# Altium schematic sheet Swich_PSU.SchDoc(Swich_PSU) (continued)

## Library data of the parts
B1 = BRIDGE3: Diode Bridge; Manufacturer: Diodes Inc; Current - Average Rectified (Io): 2A; Current - Reverse Leakage @ Vr: 5µA @ 600V; Diode Type: Single Phase; LHADescription: RECT BRIDGE GPP 600V 2A KBP; Speed: Standard Recovery >500ns, > 200mA (Io); Voltage - Forward (Vf) (Max) @ If: 1.1V @ 2A; Voltage - Peak Reverse (Max): 600V; Category: Discrete Semiconductor Products; Component Kind: Standard; ComponentLink1Description: http://www.diodes.com/datasheets/ds21205.pdf; ComponentLink1URL: http://www.diodes.com/datasheets/ds21205.pdf; ComponentLink2Description: https://www.diodes.com/assets/Datasheets/ds21205.pdf; ComponentLink2URL: https://www.diodes.com/assets/Datasheets/ds21205.pdf; Current - DC Forward (If): 2A; Manufacturer Part Number: KBP206G; Mounting Type: Through Hole; Operating Temperature: -65°C ~ 150°C (TJ); PackageCase: KBP; Packaging: Tube; Part Description: RECT BRIDGE GPP 600V 2A KBP; Part Status: Active; Pricing: 1=0.57, 10=0.48, 100=0.36, 250=0.312, 500=0.264, 1000=0.204, 2500=0.186, 5000=0.174, 10000=0.162 (USD); Pricing 1: 1=0.58, 35=0.462, 105=0.36962, 525=0.2904, 1015=0.2244 (USD); Reverse Recovery Time (trr): -; RoHS: RoHS Compliant; Stock: 7746; Stock 1: 13751; Supplier 1: Digi-Key; Supplier Device Package: KBP; Supplier Part Number 1: KBP206GDI-ND; Technology: Standard; Type: DIODE
C1 C7 C15 C18 = CAP: Cap Ceramic 10%; Manufacturer: Yageo; Manufacturer Part Number: CC0805ZRY5V9BB104; Part Description: CAP Y5V Ceramic 100nF 50V 0805 -20%, +80%  -; Dielectric: Y5V; LHADescription: CAP CER 0.1UF 50V Y5V 0805; Temperature Coefficient: Y5V (F); Tolerance: -20%, +80%; Voltage Rated: 50V; Applications: General Purpose; Capacitance: 100nF; Case Code (Imperial): 0805; Case Code (Metric): 2012; Category: Capacitors; Component Kind: Standard; ComponentLink1Description: http://www.yageo.com/documents/recent/UPY-GPHC_Y5V_6.3V-to-50V_5.pdf; ComponentLink1URL: http://www.yageo.com/documents/recent/UPY-GPHC_Y5V_6.3V-to-50V_5.pdf; ComponentLink2Description: http://www.yageo.com/documents/recent/UPY-GPHC_Y5V_6.3V-to-50V_8.pdf; ComponentLink2URL: http://www.yageo.com/documents/recent/UPY-GPHC_Y5V_6.3V-to-50V_8.pdf; ComponentLink3Description: https://datasheet.ciiva.com/144/mlccorderingcode-144209.pdf?src-supplier=Digi-Key; ComponentLink3URL: https://datasheet.ciiva.com/144/mlccorderingcode-144209.pdf?src-supplier=Digi-Key; ComponentLink4Description: https://datasheet.ciiva.com/144/mlccorderingcode-144210.pdf?src-supplier=Digi-Key; ComponentLink4URL: https://datasheet.ciiva.com/144/mlccorderingcode-144210.pdf?src-supplier=Digi-Key; ComponentLink5Description: https://datasheet.ciiva.com/144/mlccorderingcode-144211.pdf?src-supplier=Digi-Key; ComponentLink5URL: https://datasheet.ciiva.com/144/mlccorderingcode-144211.pdf?src-supplier=Digi-Key; ComponentLink6Description: https://datasheet.ciiva.com/15189/getdatasheetpartid-55882-15189238.pdf?src-supplier=Verical; ComponentLink6URL: https://datasheet.ciiva.com/15189/getdatasheetpartid-55882-15189238.pdf?src-supplier=Verical; ComponentLink7Description: https://datasheet.ciiva.com/28450/1132289-28450870.pdf?src-supplier=Element14; ComponentLink7URL: https://datasheet.ciiva.com/28450/1132289-28450870.pdf?src-supplier=Element14; ComponentLink8Description: https://datasheet.ciiva.com/13389/upy-gp-np0-16v-to-50v-8-13389351.pdf?src-supplier=Mouser; ComponentLink8URL: https://datasheet.ciiva.com/13389/upy-gp-np0-16v-to-50v-8-13389351.pdf?src-supplier=Mouser; ComponentLink9Description: https://datasheet.ciiva.com/11465/upy-gphc-y5v-6-3v-to-50v-5-11465865.pdf?src-supplier=Avnet; ComponentLink9URL: https://datasheet.ciiva.com/11465/upy-gphc-y5v-6-3v-to-50v-5-11465865.pdf?src-supplier=Avnet; ComponentLink10Description: https://datasheet.ciiva.com/16747/upy-gphc-y5v-6-3v-to-50v-5-revised-16747323.pdf?src-supplier=Future+Electronics; ComponentLink10URL: https://datasheet.ciiva.com/16747/upy-gphc-y5v-6-3v-to-50v-5-revised-16747323.pdf?src-supplier=Future+Electronics; ComponentLink11Description: https://datasheet.ciiva.com/17435/upy-gphc-y5v-6-3v-to-50v-5-17435053.pdf?src-supplier=Avnet+Europe; ComponentLink11URL: https://datasheet.ciiva.com/17435/upy-gphc-y5v-6-3v-to-50v-5-17435053.pdf?src-supplier=Avnet+Europe; ComponentLink12Description: https://datasheet.ciiva.com/29241/445331-da-01-en-kerko-chip-0805-y5v0-1uf-20-80proz50vg-29241946.pdf?src-supplier=Conrad; ComponentLink12URL: https://datasheet.ciiva.com/29241/445331-da-01-en-kerko-chip-0805-y5v0-1uf-20-80proz50vg-29241946.pdf?src-supplier=Conrad; Composition: Ceramic; Depth: 1.25mm; Dielectric Material: Ceramic; Failure Rate: -; Features: -; Halogen Free: Halogen Free; Height: 0.6mm; Height - Seated (Max): -; Lead Free: Lead Free; Lead Pitch: -; Lead Style: -; Length: 2mm; Library Name: LHA_LIB.SchLib; Material: Ceramic; Max Operating Temperature: 85°C; Min Operating Temperature: -30°C; Mount: Surface Mount; Mounting Type: Surface Mount, MLCC; Number of Pins: 2; Operating Temperature: -30°C ~ 85°C; Package Quantity: 1; PackageCase: 0805; Packaging: Cut Tape (CT); Part Status: Active; Pricing 1: 1=0.1, 10=0.031, 25=0.0216, 100=0.0141, 250=0.01152, 500=0.00986, 1000=0.00768 (USD); Pricing 2: 4000=0.01279, 8000=0.01168, 12000=0.01112, 28000=0.01056, 100000=0.00778 (USD); Radiation Hardening: No; Ratings: -; REACH SVHC: No SVHC; RoHS: RoHS Compliant; Size / Dimension: 0.079" L x 0.049" W (2.00mm x 1.25mm); Stock 1: 12304; Stock 2: 1536000; Supplier 1: Digi-Key; Supplier 2: Digi-Key; Supplier Part Number 1: 311-1361-1-ND; Supplier Part Number 2: 311-1361-2-ND; Termination: SMD/SMT; Thickness: 0.024inch; Thickness (Max): 0.024" (0.60mm); Type: CAP; Width: 0.049inch
C2 = CAP: Cap Ceramic 10%; Manufacturer: Yageo; Manufacturer Part Number: CC0805ZRY5V9BB104; Part Description: CAP Y5V Ceramic 100nF 50V 0805 -20%, +80%  -; Dielectric: Y5V; LHADescription: CAP CER 0.1UF 50V Y5V 0805; Temperature Coefficient: Y5V (F); Tolerance: -20%, +80%; Applications: General Purpose; Capacitance: 100nF; Case Code (Imperial): 0805; Case Code (Metric): 2012; Category: Capacitors; Component Kind: Standard; ComponentLink1Description: http://www.yageo.com/documents/recent/UPY-GPHC_Y5V_6.3V-to-50V_5.pdf; ComponentLink1URL: http://www.yageo.com/documents/recent/UPY-GPHC_Y5V_6.3V-to-50V_5.pdf; ComponentLink2Description: http://www.yageo.com/documents/recent/UPY-GPHC_Y5V_6.3V-to-50V_8.pdf; ComponentLink2URL: http://www.yageo.com/documents/recent/UPY-GPHC_Y5V_6.3V-to-50V_8.pdf; ComponentLink3Description: https://datasheet.ciiva.com/144/mlccorderingcode-144209.pdf?src-supplier=Digi-Key; ComponentLink3URL: https://datasheet.ciiva.com/144/mlccorderingcode-144209.pdf?src-supplier=Digi-Key; ComponentLink4Description: https://datasheet.ciiva.com/144/mlccorderingcode-144210.pdf?src-supplier=Digi-Key; ComponentLink4URL: https://datasheet.ciiva.com/144/mlccorderingcode-144210.pdf?src-supplier=Digi-Key; ComponentLink5Description: https://datasheet.ciiva.com/144/mlccorderingcode-144211.pdf?src-supplier=Digi-Key; ComponentLink5URL: https://datasheet.ciiva.com/144/mlccorderingcode-144211.pdf?src-supplier=Digi-Key; ComponentLink6Description: https://datasheet.ciiva.com/15189/getdatasheetpartid-55882-15189238.pdf?src-supplier=Verical; ComponentLink6URL: https://datasheet.ciiva.com/15189/getdatasheetpartid-55882-15189238.pdf?src-supplier=Verical; ComponentLink7Description: https://datasheet.ciiva.com/28450/1132289-28450870.pdf?src-supplier=Element14; ComponentLink7URL: https://datasheet.ciiva.com/28450/1132289-28450870.pdf?src-supplier=Element14; ComponentLink8Description: https://datasheet.ciiva.com/13389/upy-gp-np0-16v-to-50v-8-13389351.pdf?src-supplier=Mouser; ComponentLink8URL: https://datasheet.ciiva.com/13389/upy-gp-np0-16v-to-50v-8-13389351.pdf?src-supplier=Mouser; ComponentLink9Description: https://datasheet.ciiva.com/11465/upy-gphc-y5v-6-3v-to-50v-5-11465865.pdf?src-supplier=Avnet; ComponentLink9URL: https://datasheet.ciiva.com/11465/upy-gphc-y5v-6-3v-to-50v-5-11465865.pdf?src-supplier=Avnet; ComponentLink10Description: https://datasheet.ciiva.com/16747/upy-gphc-y5v-6-3v-to-50v-5-revised-16747323.pdf?src-supplier=Future+Electronics; ComponentLink10URL: https://datasheet.ciiva.com/16747/upy-gphc-y5v-6-3v-to-50v-5-revised-16747323.pdf?src-supplier=Future+Electronics; ComponentLink11Description: https://datasheet.ciiva.com/17435/upy-gphc-y5v-6-3v-to-50v-5-17435053.pdf?src-supplier=Avnet+Europe; ComponentLink11URL: https://datasheet.ciiva.com/17435/upy-gphc-y5v-6-3v-to-50v-5-17435053.pdf?src-supplier=Avnet+Europe; ComponentLink12Description: https://datasheet.ciiva.com/29241/445331-da-01-en-kerko-chip-0805-y5v0-1uf-20-80proz50vg-29241946.pdf?src-supplier=Conrad; ComponentLink12URL: https://datasheet.ciiva.com/29241/445331-da-01-en-kerko-chip-0805-y5v0-1uf-20-80proz50vg-29241946.pdf?src-supplier=Conrad; Composition: Ceramic; Depth: 1.25mm; Dielectric Material: Ceramic; Failure Rate: -; Features: -; Halogen Free: Halogen Free; Height: 0.6mm; Height - Seated (Max): -; Lead Free: Lead Free; Lead Pitch: -; Lead Style: -; Length: 2mm; Library Name: LHA_LIB.SchLib; Material: Ceramic; Max Operating Temperature: 85°C; Min Operating Temperature: -30°C; Mount: Surface Mount; Mounting Type: Surface Mount, MLCC; Number of Pins: 2; Operating Temperature: -30°C ~ 85°C; Package Quantity: 1; PackageCase: 0805; Packaging: Cut Tape (CT); Part Status: Active; Pricing 1: 1=0.1, 10=0.031, 25=0.0216, 100=0.0141, 250=0.01152, 500=0.00986, 1000=0.00768 (USD); Pricing 2: 4000=0.01279, 8000=0.01168, 12000=0.01112, 28000=0.01056, 100000=0.00778 (USD); Radiation Hardening: No; Ratings: -; REACH SVHC: No SVHC; RoHS: RoHS Compliant; Size / Dimension: 0.079" L x 0.049" W (2.00mm x 1.25mm); Stock 1: 12304; Stock 2: 1536000; Supplier 1: Digi-Key; Supplier 2: Digi-Key; Supplier Part Number 1: 311-1361-1-ND; Supplier Part Number 2: 311-1361-2-ND; Termination: SMD/SMT; Thickness: 0.024inch; Thickness (Max): 0.024" (0.60mm); Type: CAP; Width: 0.049inch
C4 = CAP: Cap Ceramic 10%; Manufacturer: Murata; Manufacturer Part Number: GRM31A5C3A220JW01D; Part Description: CAP C0G  22pF  1206 5%; Dielectric: C0G; LHADescription: CAP CER 22PF 1KV C0G/NP0 1206; Tolerance: 5%; Voltage Rating: 1kV; Capacitance: 22pF; Case Code (Imperial): 1206; Case Code (Metric): 3216; Category: Capacitors; Component Kind: Standard; ComponentLink1Description: https://datasheet.ciiva.com/7829/1666664-7829030.pdf?src-supplier=Element14; ComponentLink1URL: https://datasheet.ciiva.com/7829/1666664-7829030.pdf?src-supplier=Element14; ComponentLink2Description: https://datasheet.ciiva.com/13352/c02e-13352863.pdf?src-supplier=Mouser; ComponentLink2URL: https://datasheet.ciiva.com/13352/c02e-13352863.pdf?src-supplier=Mouser; ComponentLink6Description: https://datasheet.ciiva.com/2988/kem-c1003-c0g-smd-2988707.pdf?src-supplier=Avnet; ComponentLink6URL: https://datasheet.ciiva.com/2988/kem-c1003-c0g-smd-2988707.pdf?src-supplier=Avnet; ComponentLink7Description: https://datasheet.ciiva.com/14602/70095383-14602200.pdf?src-supplier=Allied+Electronics; ComponentLink7URL: https://datasheet.ciiva.com/14602/70095383-14602200.pdf?src-supplier=Allied+Electronics; ComponentLink8Description: https://datasheet.ciiva.com/11463/kem-c1003-c0g-smd-11463530.pdf?src-supplier=Avnet; ComponentLink8URL: https://datasheet.ciiva.com/11463/kem-c1003-c0g-smd-11463530.pdf?src-supplier=Avnet; ComponentLink9Description: https://datasheet.ciiva.com/18194/getdatasheetpartid-135192-18194074.pdf?src-supplier=Verical; ComponentLink9URL: https://datasheet.ciiva.com/18194/getdatasheetpartid-135192-18194074.pdf?src-supplier=Verical; ComponentLink10Description: https://datasheet.ciiva.com/28672/1789732-28672843.pdf?src-supplier=Element14; ComponentLink10URL: https://datasheet.ciiva.com/28672/1789732-28672843.pdf?src-supplier=Element14; ComponentLink11Description: https://datasheet.ciiva.com/7729/1691965-7729775.pdf?src-supplier=Element14; ComponentLink11URL: https://datasheet.ciiva.com/7729/1691965-7729775.pdf?src-supplier=Element14; ComponentLink12Description: https://datasheet.ciiva.com/14586/70095383-14586767.pdf?src-supplier=Allied+Electronics; ComponentLink12URL: https://datasheet.ciiva.com/14586/70095383-14586767.pdf?src-supplier=Allied+Electronics; Depth: 1.6mm; Height: 1mm; Length: 3.2mm; Library Name: LHA_LIB.SchLib; Max Operating Temperature: 125°C; Min Operating Temperature: -55°C; Mount: Surface Mount; Package Quantity: 4000; PackageCase: 1206; Packaging: Tape and Reel; Pricing 1: 1=0.41000, 10=0.29200, 50=0.22080, 100=0.19230, 500=0.14240, 1000=0.12105 (USD); REACH SVHC: No SVHC; RoHS: Yes; RoHS Compliant: Yes; Series: GRM; Stock 1: 271065; Supplier 1: Digi-Key; Supplier Part Number 1: 490-14673-1-ND; Termination: SMD/SMT; Thickness: 0.039inch; Width: 0.063inch
C5 C26 = CAP: Cap Polyester; Manufacturer: TDK Corporation; Manufacturer Part Number: CD12-E2GA222MYNS; Part Description: CAP X1 Ceramic 2.2nF 250VAC Radial, Disc ±20%  10.00mm; Dielectric: X1; Dielectric Material: Ceramic; LHADescription: CAP CER 2200PF 250VAC RADIAL  X1; Temperature Coefficient: E; Tolerance: ±20%; Voltage Rated: 250VAC; Applications: Safety; Capacitance: 2.2nF; Category: Capacitors; Component Kind: Standard; ComponentLink1Description: http://www.tdk.com/pdf/e4b3_cd.pdf; ComponentLink1URL: http://www.tdk.com/pdf/e4b3_cd.pdf; ComponentLink2Description: http://media.digikey.com/pdf/Data%20Sheets/TDK%20PDFs/CD_Series.pdf; ComponentLink2URL: http://media.digikey.com/pdf/Data%20Sheets/TDK%20PDFs/CD_Series.pdf; ComponentLink3Description: http://www.molex.com/pdm_docs/ats/ATS-639041600.pdf?src-supplier=Digi-Key; ComponentLink3URL: http://www.molex.com/pdm_docs/ats/ATS-639041600.pdf?src-supplier=Digi-Key; Failure Rate: -; Features: High Voltage; Height - Seated (Max): 0.610" (15.50mm); Lead Pitch: 10.00mm; Lead Style: Straight; Library Name: LHA_LIB.SchLib; Mounting Type: Through Hole; Operating Temperature: -25°C ~ 105°C; PackageCase: Radial, Disc; Packaging: Bulk; Part Status: Obsolete; Pricing: 1=0.5, 10=0.353, 100=0.2325, 250=0.19808, 500=0.17224, 1000=0.1464, 5000=0.12918, 10000=0.12057, 25000=0.11626 (USD); Pricing 1: 1=0.55, 10=0.388 (USD); Pricing 2: 1=124.74000, 5=117.18000, 10=113.40000, 25=109.62000 (USD); Ratings: X1; RoHS: RoHS Compliant; Size / Dimension: 0.453" Dia (11.50mm); Stock: 322844; Stock 1: 12; Stock 2: 2; Supplier 1: Digi-Key; Supplier 2: Digi-Key; Supplier Part Number 1: 445-2411-ND; Supplier Part Number 2: WM19323-ND; Thickness (Max): -; Type: CAP
C6 = CAP: Cap Ceramic 10%; Manufacturer: KEMET; Manufacturer Part Number: C1210C392KGRACTU; Part Description: CAP X7R Ceramic 3.9nF 2kV 1210 10%  -; Dielectric: X7R; LHADescription: CAP CER 3900PF 2KV X7R 1210; Applications: SMPS Filtering; Capacitance: 3.9nF; Case Code (Imperial): 1210; Case Code (Metric): 3225; Category: Capacitors; Component Kind: Standard; ComponentLink1Description: http://components.arrow.com/part/dataview/48187724S8617532N7429; ComponentLink1URL: http://components.arrow.com/part/dataview/48187724S8617532N7429; ComponentLink2Description: http://www.mouser.com/ds/2/212/KEM_C1010_X7R_HV_SMD-345925.pdf; ComponentLink2URL: http://www.mouser.com/ds/2/212/KEM_C1010_X7R_HV_SMD-345925.pdf; ComponentLink3Description: http://www.kemet.com/docfinder?Partnumber=C1210C392KGRACTU; ComponentLink3URL: http://www.kemet.com/docfinder?Partnumber=C1210C392KGRACTU; Depth: 2.5mm; Dielectric Material: Ceramic; Failure Rate: -; Features: High Voltage; Height - Seated (Max): -; Lead Pitch: -; Lead Style: -; Length: 0.126inch; Library Name: LHA_LIB.SchLib; Max Operating Temperature: 125°C; Min Operating Temperature: -55°C; Mounting Type: Surface Mount, MLCC; Operating Temperature: -55°C ~ 125°C; Package Quantity: 1; PackageCase: 1210; Packaging: Tape and Reel; Part Status: Active; Pricing 1: 2000=0.39588, 4000=0.3754 (USD); Ratings: -; RoHS: RoHS Compliant; Size / Dimension: 0.126" L x 0.098" W (3.20mm x 2.50mm); Stock 1: 0; Supplier 1: Digi-Key; Supplier Part Number 1: 399-7234-2-ND; Temperature Coefficient: X7R; Termination: SMD/SMT; Thickness: 0.091inch; Thickness (Max): 0.091" (2.30mm); Tolerance: 10%; Voltage Rated: 2kV; Width: 0.098inch
C8 C9 = CAP POL: Electrolytic Capacitor; Manufacturer: KEMET; Manufacturer Part Number: ESW337M050AH4EA; Part Description: CAP   330uF LOW ESR 50V Radial 20%  5mm; ESR (Equivalent Series Resistance): 90mR; LHADescription: Aluminum Electrolytic Capacitors - Leaded 50volts 330uF 20%; Life (Hours): 5000Hour; Polarity: Polar; Ripple Current: 566.5mA; Tolerance: 20%; Capacitance: 330uF LOW ESR; Category: Capacitors; Component Kind: Standard; Height - Seated (Max): 21mm; Impedance: 90mR; Lead Pitch: 5mm; Length: 19mm; Library Name: LHA_LIB.SchLib; Max Operating Temperature: 105°C; Min Operating Temperature: -40°C; Mount: Through Hole; Operating Temperature: -40°C ~ 105°C; Package Quantity: 1; PackageCase: Radial; Packaging: Tape and Reel; Pricing 1: 1=0.93000, 10=0.68500, 50=0.54800, 100=0.46980 (USD); RoHS: Yes; RoHS Compliant: Yes; Series: ESW; Stock 1: 4304; Supplier 1: Digi-Key; Supplier Part Number 1: 399-6661-1-ND; Termination: Radial
  C8: ComponentLink1Description: http://www.vishay.com/docs/25008/eke.pdf; ComponentLink1URL: http://www.vishay.com/docs/25008/eke.pdf; ComponentLink2Description: http://www.mouser.com/ds/2/212/KEM_A4009_ESW-1102613.pdf?src-supplier=Mouser; ComponentLink2URL: http://www.mouser.com/ds/2/212/KEM_A4009_ESW-1102613.pdf?src-supplier=Mouser; ComponentLink3Description: https://search.kemet.com/component-edge/download/datasheet/ESW337M050AH4EA.pdf?src-supplier=Digi-Key; ComponentLink3URL: https://search.kemet.com/component-edge/download/datasheet/ESW337M050AH4EA.pdf?src-supplier=Digi-Key
  C9: ComponentLink1Description: http://www.mouser.com/ds/2/212/KEM_A4009_ESW-1102613.pdf?src-supplier=Mouser; ComponentLink1URL: http://www.mouser.com/ds/2/212/KEM_A4009_ESW-1102613.pdf?src-supplier=Mouser; ComponentLink2Description: https://search.kemet.com/component-edge/download/datasheet/ESW337M050AH4EA.pdf?src-supplier=Digi-Key; ComponentLink2URL: https://search.kemet.com/component-edge/download/datasheet/ESW337M050AH4EA.pdf?src-supplier=Digi-Key
C10 = CAP POL: Electrolytic Capacitor; Manufacturer: Rubycon; Manufacturer Part Number: 50ZL100MEFCT78X11.5; Part Description: CAP   100uF LOW ESR 50V Radial 20%  3.5mm; Impedance: 74mR; LHADescription: Cap Aluminum 100uF 50V 20% (8 X 11.5mm) Radial Aluminum Cylindrical Can 3.5mm 724mA 3000 hr 105°C Ammo Pack; Life (Hours): 3000Hour; Ripple Current: 724mA; Tolerance: 20%; Capacitance: 100uF LOW ESR; Category: Capacitors; Component Kind: Standard; ComponentLink1Description: http://www.vishay.com/docs/25008/eke.pdf; ComponentLink1URL: http://www.vishay.com/docs/25008/eke.pdf; ComponentLink2Description: https://datasheet.ciiva.com/16375/e-vr-16375770.pdf?src-supplier=Future+Electronics; ComponentLink2URL: https://datasheet.ciiva.com/16375/e-vr-16375770.pdf?src-supplier=Future+Electronics; ComponentLink3Description: https://datasheet.ciiva.com/7781/e-vr-7781740.pdf?src-supplier=Element14; ComponentLink3URL: https://datasheet.ciiva.com/7781/e-vr-7781740.pdf?src-supplier=Element14; ComponentLink4Description: https://datasheet.ciiva.com/17525/e-vr-17525677.pdf?src-supplier=Avnet+Europe; ComponentLink4URL: https://datasheet.ciiva.com/17525/e-vr-17525677.pdf?src-supplier=Avnet+Europe; ComponentLink5Description: https://datasheet.ciiva.com/11686/e-vr-11686088.pdf?src-supplier=Avnet; ComponentLink5URL: https://datasheet.ciiva.com/11686/e-vr-11686088.pdf?src-supplier=Avnet; ComponentLink6Description: https://datasheet.ciiva.com/46381/1909356-46381755.pdf?src-supplier=Element14; ComponentLink6URL: https://datasheet.ciiva.com/46381/1909356-46381755.pdf?src-supplier=Element14; ComponentLink7Description: https://datasheet.ciiva.com/14600/70187464-14600942.pdf?src-supplier=Allied+Electronics; ComponentLink7URL: https://datasheet.ciiva.com/14600/70187464-14600942.pdf?src-supplier=Allied+Electronics; ComponentLink8Description: https://datasheet.ciiva.com/6328/e-vr-6328815.pdf?src-supplier=Digi-Key; ComponentLink8URL: https://datasheet.ciiva.com/6328/e-vr-6328815.pdf?src-supplier=Digi-Key; ComponentLink9Description: https://datasheet.ciiva.com/6328/e-yxj-6328247.pdf?src-supplier=Digi-Key; ComponentLink9URL: https://datasheet.ciiva.com/6328/e-yxj-6328247.pdf?src-supplier=Digi-Key; ComponentLink10Description: https://datasheet.ciiva.com/6328/e-yxj-6328248.pdf?src-supplier=Digi-Key; ComponentLink10URL: https://datasheet.ciiva.com/6328/e-yxj-6328248.pdf?src-supplier=Digi-Key; ComponentLink11Description: https://datasheet.ciiva.com/6318/e-zl-6318059.pdf?src-supplier=Digi-Key; ComponentLink11URL: https://datasheet.ciiva.com/6318/e-zl-6318059.pdf?src-supplier=Digi-Key; Height - Seated (Max): 13mm; Lead Pitch: 3.5mm; Library Name: LHA_LIB.SchLib; Max Operating Temperature: 105°C; Min Operating Temperature: -40°C; Mount: Through Hole; Operating Temperature: -40°C ~ 105°C; Package Quantity: 2000; PackageCase: Radial; Packaging: Tape and Reel; Pricing 1: 1=0.41000, 10=0.28500, 50=0.19920, 100=0.17080, 500=0.12808 (USD); RoHS: Yes; Stock 1: 3785; Supplier 1: Digi-Key; Supplier Part Number 1: 1189-3773-1-ND
C11 = CAP POL: Aluminium Electrolytic Cap; Part Description: CAP Electrolytic Aluminium 180uF 400V R18mm x H39mm max x P7.5mm 20%; Hight: 39mm max; LHADescription: Aluminum Electrolytic Capacitor, Polarized, Aluminum (wet), 400V, 20% +Tol, 20% -Tol, 180uF, Through Hole Mount; Tolerance: 20%; Capacitance: 180uF; Category: Capacitors; Component Kind: Standard; Diameter: 20mm max; Dielectric: Electrolytic; Dielectric Material: Aluminium; Lead Pitch: 7.5mm; Library Name: LHA_LIB.SchLib; PackageCase: R18mm x H39mm max x P7.5mm; Pricing 1: 1=4.55000, 10=4.09900, 50=3.55240, 100=3.09690, 500=2.55040, 1000=2.37615 (USD); RoHS: Yes; Stock 1: 119; Supplier 1: Digi-Key
C12 = CAP POL: Electrolytic Capacitor; Manufacturer: Wurth Electronics; Manufacturer Part Number: 860020672011; Part Description: CAP   22uF LOW ESR 50V Radial 20% 5mm 3.5mm; LHADescription: Aluminium Electrolytic Capacitor, Wcap-Atg5 Series, 22 F, 20%, 50 V, 5 Mm, Radial Leaded Rohs Compliant: Yes; Life (Hours): 2000Hour; Polarity: Polar; Ripple Current: 60mA; Tolerance: 20%; Voltage Rated: 50V; Capacitance: 22uF LOW ESR; Category: Capacitors; Component Kind: Standard; ComponentLink1Description: http://www.rubycon.co.jp/en/catalog/e_pdfs/aluminum/e_zl.pdf; ComponentLink1URL: http://www.rubycon.co.jp/en/catalog/e_pdfs/aluminum/e_zl.pdf; ComponentLink2Description: http://www.farnell.com/datasheets/1879653.pdf?src-supplier=Farnell; ComponentLink2URL: http://www.farnell.com/datasheets/1879653.pdf?src-supplier=Farnell; ComponentLink3Description: https://katalog.we-online.de/pbs/datasheet/860020672011.pdf?src-supplier=Digi-Key; ComponentLink3URL: https://katalog.we-online.de/pbs/datasheet/860020672011.pdf?src-supplier=Digi-Key; ComponentLink4Description: http://katalog.we-online.de/pbs/datasheet/860020672011.pdf?src-supplier=Digi-Key; ComponentLink4URL: http://katalog.we-online.de/pbs/datasheet/860020672011.pdf?src-supplier=Digi-Key; ComponentLink5Description: http://www.farnell.com/datasheets/1879653.pdf?src-supplier=Element14; ComponentLink5URL: http://www.farnell.com/datasheets/1879653.pdf?src-supplier=Element14; Diameter: 5mm; Height: 11mm; Height - Seated (Max): 0.492inch; Lead Pitch: 3.5mm; Library Name: LHA_LIB.SchLib; Max Operating Temperature: 105°C; Min Operating Temperature: -40°C; Mount: Through Hole; Operating Temperature: -40°C ~ 105°C; Package Quantity: 2000; PackageCase: Radial; Packaging: Tape and Reel; Pricing 1: 1=0.11000, 50=0.09000, 100=0.07900, 250=0.07000, 500=0.06100, 1000=0.05800 (USD); REACH SVHC: Unknown; RoHS: Yes; RoHS Compliant: Yes; Stock 1: 1276; Supplier 1: Digi-Key; Supplier Part Number 1: 732-8857-1-ND
C13 = CAP: Cap Ceramic 10%; Manufacturer: Samsung; Manufacturer Part Number: CL21B562KBANNNC; Part Description: CAP X7R  5.6nF 50V 0805 10%; Dielectric: X7R; LHADescription: Cap Ceramic 0.0056uF 50V X7R 10% SMD 0805 125C Paper T/R; Voltage Rated: 50V; Capacitance: 5.6nF; Case Code (Imperial): 0805; Case Code (Metric): 2012; Category: Capacitors; Component Kind: Standard; ComponentLink1Description: http://product.tdk.com/capacitor/mlcc/en/documents/mlcc_commercial_general_en.pdf; ComponentLink1URL: http://product.tdk.com/capacitor/mlcc/en/documents/mlcc_commercial_general_en.pdf; ComponentLink2Description: https://product.tdk.com/info/en/catalog/datasheets/mlcc_commercial_general_en.pdf; ComponentLink2URL: https://product.tdk.com/info/en/catalog/datasheets/mlcc_commercial_general_en.pdf; ComponentLink3Description: https://datasheet.ciiva.com/7842/1851736-7842380.pdf?src-supplier=Element14; ComponentLink3URL: https://datasheet.ciiva.com/7842/1851736-7842380.pdf?src-supplier=Element14; ComponentLink4Description: https://datasheet.ciiva.com/26878/getdatasheetpartid-687123-26878096.pdf?src-supplier=Verical; ComponentLink4URL: https://datasheet.ciiva.com/26878/getdatasheetpartid-687123-26878096.pdf?src-supplier=Verical; ComponentLink5Description: https://datasheet.ciiva.com/29199/455237-da-01-en-vs-kondensator-0805-29199351.pdf?src-supplier=Conrad; ComponentLink5URL: https://datasheet.ciiva.com/29199/455237-da-01-en-vs-kondensator-0805-29199351.pdf?src-supplier=Conrad; ComponentLink6Description: https://datasheet.ciiva.com/16199/cl05b104kq5nnnc-16199275.pdf?src-supplier=Future+Electronics; ComponentLink6URL: https://datasheet.ciiva.com/16199/cl05b104kq5nnnc-16199275.pdf?src-supplier=Future+Electronics; Depth: 1.25mm; Height: 0.65mm; Length: 2mm; Library Name: LHA_LIB.SchLib; Material: Ceramic; Max Operating Temperature: 125°C; Min Operating Temperature: -55°C; Mount: Surface Mount; Package Quantity: 1; PackageCase: 0805; Packaging: Tape and Reel; Pricing 1: 4000=0.01569, 8000=0.01432 (USD); Radiation Hardening: No; RoHS: Yes; Stock 1: 224000; Supplier 1: Digi-Key; Supplier Part Number 1: 1276-2535-2-ND; Thickness: 0.03inch; Tolerance: 10%; Width: 0.049inch
C14 = CAP: Cap Ceramic 10%; Manufacturer: Samsung; Manufacturer Part Number: CL31A106KAHNNNE; Part Description: CAP X5R  10uF 25V 1206 10%; Dielectric: X5R; LHADescription: CAP CER 10UF 25V X5R 1206; Voltage Rated: 25V; Capacitance: 10uF; Case Code (Imperial): 1206; Case Code (Metric): 3216; Category: Capacitors; Component Kind: Standard; ComponentLink1Description: http://www.murata.com/~/media/webrenewal/support/library/catalog/products/capacitor/mlcc/c02e.ashx; ComponentLink1URL: http://www.murata.com/~/media/webrenewal/support/library/catalog/products/capacitor/mlcc/c02e.ashx; ComponentLink2Description: https://datasheet.ciiva.com/27056/2014035-27056208.pdf?src-supplier=Jameco; ComponentLink2URL: https://datasheet.ciiva.com/27056/2014035-27056208.pdf?src-supplier=Jameco; ComponentLink3Description: https://datasheet.ciiva.com/7812/cx7r-7812103.pdf?src-supplier=Element14; ComponentLink3URL: https://datasheet.ciiva.com/7812/cx7r-7812103.pdf?src-supplier=Element14; ComponentLink4Description: https://datasheet.ciiva.com/7812/cx7r-7812104.pdf?src-supplier=Element14; ComponentLink4URL: https://datasheet.ciiva.com/7812/cx7r-7812104.pdf?src-supplier=Element14; ComponentLink5Description: https://datasheet.ciiva.com/46441/1818074-46441208.pdf?src-supplier=Element14; ComponentLink5URL: https://datasheet.ciiva.com/46441/1818074-46441208.pdf?src-supplier=Element14; ComponentLink6Description: https://datasheet.ciiva.com/151/cx7r-151913.pdf?src-supplier=Digi-Key; ComponentLink6URL: https://datasheet.ciiva.com/151/cx7r-151913.pdf?src-supplier=Digi-Key; ComponentLink7Description: https://datasheet.ciiva.com/7812/cx7r-7812105.pdf?src-supplier=Element14; ComponentLink7URL: https://datasheet.ciiva.com/7812/cx7r-7812105.pdf?src-supplier=Element14; ComponentLink8Description: https://datasheet.ciiva.com/16291/cx7r-16291545.pdf?src-supplier=Future+Electronics; ComponentLink8URL: https://datasheet.ciiva.com/16291/cx7r-16291545.pdf?src-supplier=Future+Electronics; ComponentLink9Description: https://datasheet.ciiva.com/151/cx7r-151914.pdf?src-supplier=Digi-Key; ComponentLink9URL: https://datasheet.ciiva.com/151/cx7r-151914.pdf?src-supplier=Digi-Key; ComponentLink10Description: https://datasheet.ciiva.com/151/cx7r-151915.pdf?src-supplier=Digi-Key; ComponentLink10URL: https://datasheet.ciiva.com/151/cx7r-151915.pdf?src-supplier=Digi-Key; ComponentLink11Description: https://datasheet.ciiva.com/25730/cl21a106koqnnng-25730226.pdf?src-supplier=Digi-Key; ComponentLink11URL: https://datasheet.ciiva.com/25730/cl21a106koqnnng-25730226.pdf?src-supplier=Digi-Key; ComponentLink12Description: https://datasheet.ciiva.com/29199/454932-da-01-en-vs-kondensator-0805-29199423.pdf?src-supplier=Conrad; ComponentLink12URL: https://datasheet.ciiva.com/29199/454932-da-01-en-vs-kondensator-0805-29199423.pdf?src-supplier=Conrad; ComponentLink13Description: https://datasheet.ciiva.com/25710/cl31a106kahnnne-25710837.pdf?src-supplier=Digi-Key; ComponentLink13URL: https://datasheet.ciiva.com/25710/cl31a106kahnnne-25710837.pdf?src-supplier=Digi-Key; ComponentLink14Description: https://datasheet.ciiva.com/15195/getdatasheetpartid-355210-15195990.pdf?src-supplier=Verical; ComponentLink14URL: https://datasheet.ciiva.com/15195/getdatasheetpartid-355210-15195990.pdf?src-supplier=Verical; ComponentLink15Description: https://datasheet.ciiva.com/29201/452519-da-01-en-vs-kondensator-1206-29201124.pdf?src-supplier=Conrad; ComponentLink15URL: https://datasheet.ciiva.com/29201/452519-da-01-en-vs-kondensator-1206-29201124.pdf?src-supplier=Conrad; Contact Plating: Tin; Depth: 1.6mm; Height: 1.6mm; Lead Free: Lead Free; Length: 3.2mm; Library Name: LHA_LIB.SchLib; Material: Ceramic; Max Operating Temperature: 85°C; Min Operating Temperature: -55°C; Mount: Surface Mount; PackageCase: 1206; Packaging: Tape and Reel; Pricing 1: 1=0.24000, 10=0.16500, 100=0.08360, 500=0.05720, 1000=0.05060 (USD); Radiation Hardening: No; RoHS: Yes; Stock 1: 383465; Supplier 1: Digi-Key; Supplier Part Number 1: 1276-1075-1-ND; Thickness: 0.071inch; Tolerance: 10%; Width: 0.063inch
C16 = CAP POL: Electrolytic Capacitor; Manufacturer: Rubycon; Manufacturer Part Number: 50ZL100MEFCT78X11.5; Part Description: CAP   100uF LOW ESR 50V Radial 20%  3.5mm; Impedance: 74mR; LHADescription: Cap Aluminum 100uF 50V 20% (8 X 11.5mm) Radial Aluminum Cylindrical Can 3.5mm 724mA 3000 hr 105°C Ammo Pack; Life (Hours): 3000Hour; Ripple Current: 724mA; Tolerance: 20%; Voltage Rated: 50V; Capacitance: 100uF LOW ESR; Category: Capacitors; Component Kind: Standard; ComponentLink1Description: http://www.vishay.com/docs/25008/eke.pdf; ComponentLink1URL: http://www.vishay.com/docs/25008/eke.pdf; ComponentLink2Description: https://datasheet.ciiva.com/16375/e-vr-16375770.pdf?src-supplier=Future+Electronics; ComponentLink2URL: https://datasheet.ciiva.com/16375/e-vr-16375770.pdf?src-supplier=Future+Electronics; ComponentLink3Description: https://datasheet.ciiva.com/7781/e-vr-7781740.pdf?src-supplier=Element14; ComponentLink3URL: https://datasheet.ciiva.com/7781/e-vr-7781740.pdf?src-supplier=Element14; ComponentLink4Description: https://datasheet.ciiva.com/17525/e-vr-17525677.pdf?src-supplier=Avnet+Europe; ComponentLink4URL: https://datasheet.ciiva.com/17525/e-vr-17525677.pdf?src-supplier=Avnet+Europe; ComponentLink5Description: https://datasheet.ciiva.com/11686/e-vr-11686088.pdf?src-supplier=Avnet; ComponentLink5URL: https://datasheet.ciiva.com/11686/e-vr-11686088.pdf?src-supplier=Avnet; ComponentLink6Description: https://datasheet.ciiva.com/46381/1909356-46381755.pdf?src-supplier=Element14; ComponentLink6URL: https://datasheet.ciiva.com/46381/1909356-46381755.pdf?src-supplier=Element14; ComponentLink7Description: https://datasheet.ciiva.com/14600/70187464-14600942.pdf?src-supplier=Allied+Electronics; ComponentLink7URL: https://datasheet.ciiva.com/14600/70187464-14600942.pdf?src-supplier=Allied+Electronics; ComponentLink8Description: https://datasheet.ciiva.com/6328/e-vr-6328815.pdf?src-supplier=Digi-Key; ComponentLink8URL: https://datasheet.ciiva.com/6328/e-vr-6328815.pdf?src-supplier=Digi-Key; ComponentLink9Description: https://datasheet.ciiva.com/6328/e-yxj-6328247.pdf?src-supplier=Digi-Key; ComponentLink9URL: https://datasheet.ciiva.com/6328/e-yxj-6328247.pdf?src-supplier=Digi-Key; ComponentLink10Description: https://datasheet.ciiva.com/6328/e-yxj-6328248.pdf?src-supplier=Digi-Key; ComponentLink10URL: https://datasheet.ciiva.com/6328/e-yxj-6328248.pdf?src-supplier=Digi-Key; ComponentLink11Description: https://datasheet.ciiva.com/6318/e-zl-6318059.pdf?src-supplier=Digi-Key; ComponentLink11URL: https://datasheet.ciiva.com/6318/e-zl-6318059.pdf?src-supplier=Digi-Key; Height - Seated (Max): 13mm; Lead Pitch: 3.5mm; Library Name: LHA_LIB.SchLib; Max Operating Temperature: 105°C; Min Operating Temperature: -40°C; Mount: Through Hole; Operating Temperature: -40°C ~ 105°C; Package Quantity: 2000; PackageCase: Radial; Packaging: Tape and Reel; Pricing 1: 1=0.41000, 10=0.28500, 50=0.19920, 100=0.17080, 500=0.12808 (USD); RoHS: Yes; Stock 1: 3785; Supplier 1: Digi-Key; Supplier Part Number 1: 1189-3773-1-ND
C17 = CAP: Cap Ceramic 20%; Manufacturer: TDK Corporation; Manufacturer Part Number: C3225X7R1C226M250AC; Part Description: CAP X7R Ceramic 22uF 16V 1210 ±20%  -; Dielectric: X7R; LHADescription: CAP CER 22UF 16V X7R 1210; Voltage Rated: 16V; Applications: General Purpose; Capacitance: 22uF; Case Code (Imperial): 1210; Case Code (Metric): 3225; Category: Capacitors; Component Kind: Standard; ComponentLink1Description: https://product.tdk.com/info/en/catalog/datasheets/mlcc_commercial_general_en.pdf; ComponentLink1URL: https://product.tdk.com/info/en/catalog/datasheets/mlcc_commercial_general_en.pdf; ComponentLink2Description: https://datasheet.ciiva.com/24216/c3225x7r1c226m250ac-265468-24216381.pdf?src-supplier=Mouser; ComponentLink2URL: https://datasheet.ciiva.com/24216/c3225x7r1c226m250ac-265468-24216381.pdf?src-supplier=Mouser; ComponentLink3Description: https://datasheet.ciiva.com/6252/tdkmlcccaprange-6252933.pdf?src-supplier=Digi-Key; ComponentLink3URL: https://datasheet.ciiva.com/6252/tdkmlcccaprange-6252933.pdf?src-supplier=Digi-Key; ComponentLink4Description: https://datasheet.ciiva.com/7975/639999-7975159.pdf?src-supplier=Element14; ComponentLink4URL: https://datasheet.ciiva.com/7975/639999-7975159.pdf?src-supplier=Element14; ComponentLink5Description: https://datasheet.ciiva.com/6145/1571323-6145128.pdf?src-supplier=Farnell; ComponentLink5URL: https://datasheet.ciiva.com/6145/1571323-6145128.pdf?src-supplier=Farnell; ComponentLink6Description: https://datasheet.ciiva.com/28620/1571323-28620648.pdf?src-supplier=Element14; ComponentLink6URL: https://datasheet.ciiva.com/28620/1571323-28620648.pdf?src-supplier=Element14; ComponentLink7Description: https://datasheet.ciiva.com/6252/tdkmlcccaprange-6252937.pdf?src-supplier=Digi-Key; ComponentLink7URL: https://datasheet.ciiva.com/6252/tdkmlcccaprange-6252937.pdf?src-supplier=Digi-Key; ComponentLink8Description: https://datasheet.ciiva.com/17487/e412-c-17487337.pdf?src-supplier=Avnet+Europe; ComponentLink8URL: https://datasheet.ciiva.com/17487/e412-c-17487337.pdf?src-supplier=Avnet+Europe; ComponentLink9Description: https://datasheet.ciiva.com/15192/getdatasheetpartid-715071-15192314.pdf?src-supplier=Verical; ComponentLink9URL: https://datasheet.ciiva.com/15192/getdatasheetpartid-715071-15192314.pdf?src-supplier=Verical; ComponentLink10Description: https://datasheet.ciiva.com/6252/tdkmlcccaprange-6252940.pdf?src-supplier=Digi-Key; ComponentLink10URL: https://datasheet.ciiva.com/6252/tdkmlcccaprange-6252940.pdf?src-supplier=Digi-Key; ComponentLink11Description: https://datasheet.ciiva.com/7878/1571323-7878125.pdf?src-supplier=Element14; ComponentLink11URL: https://datasheet.ciiva.com/7878/1571323-7878125.pdf?src-supplier=Element14; ComponentLink12Description: https://datasheet.ciiva.com/16199/e412-c-16199357.pdf?src-supplier=Future+Electronics; ComponentLink12URL: https://datasheet.ciiva.com/16199/e412-c-16199357.pdf?src-supplier=Future+Electronics; Composition: Ceramic; Depth: 2.5mm; Dielectric Material: Ceramic; Failure Rate: -; Features: Low ESL; Height: 2.5mm; Height - Seated (Max): -; Lead Free: Lead Free; Lead Pitch: -; Lead Style: -; Length: 3.2mm; Max Operating Temperature: 125°C; Min Operating Temperature: -55°C; Mount: Surface Mount; Mounting Type: Surface Mount, MLCC; Number of Pins: 2; Operating Temperature: -55°C ~ 125°C; Package Quantity: 1; PackageCase: 1210; Packaging: Tape & Reel (TR); Part Status: Active; Pricing 1: 1000=0.17765, 2000=0.16720, 5000=0.15675, 10000=0.14630, 25000=0.14108, 50000=0.13585 (USD); Radiation Hardening: No; Ratings: -; REACH SVHC: No SVHC; RoHS: RoHS Compliant; Size / Dimension: 0.126" L x 0.098" W (3.20mm x 2.50mm); Stock 1: 467263; Supplier 1: Digi-Key; Supplier Part Number 1: 445-3955-2-ND; Temperature Coefficient: X7R; Termination: SMD/SMT; Thickness: 0.11inch; Thickness (Max): 0.110" (2.80mm); Tolerance: ±20%; Type: CAP; Weight: 0.000564oz; Width: 0.098inch
C20 C24 = CAP: Cap Ceramic 10%; Manufacturer: KEMET; Manufacturer Part Number: C1206C105K5RACTU; Part Description: CAP X7R Ceramic 1uF 50V 1206 10%; Dielectric: X7R; LHADescription: Multilayer Ceramic Capacitors MLCC - SMD/SMT 50volts 1uF X7R 10%; Capacitance: 1uF; Case Code (Imperial): 1206; Case Code (Metric): 3216; Category: Capacitors; Component Kind: Standard; ComponentLink1Description: http://www.murata.com/~/media/webrenewal/support/library/catalog/products/capacitor/mlcc/c02e.ashx; ComponentLink1URL: http://www.murata.com/~/media/webrenewal/support/library/catalog/products/capacitor/mlcc/c02e.ashx; ComponentLink2Description: https://datasheet.ciiva.com/27056/2014035-27056208.pdf?src-supplier=Jameco; ComponentLink2URL: https://datasheet.ciiva.com/27056/2014035-27056208.pdf?src-supplier=Jameco; ComponentLink3Description: https://datasheet.ciiva.com/7812/cx7r-7812103.pdf?src-supplier=Element14; ComponentLink3URL: https://datasheet.ciiva.com/7812/cx7r-7812103.pdf?src-supplier=Element14; ComponentLink4Description: https://datasheet.ciiva.com/7812/cx7r-7812104.pdf?src-supplier=Element14; ComponentLink4URL: https://datasheet.ciiva.com/7812/cx7r-7812104.pdf?src-supplier=Element14; ComponentLink5Description: https://datasheet.ciiva.com/46441/1818074-46441208.pdf?src-supplier=Element14; ComponentLink5URL: https://datasheet.ciiva.com/46441/1818074-46441208.pdf?src-supplier=Element14; ComponentLink6Description: https://datasheet.ciiva.com/151/cx7r-151913.pdf?src-supplier=Digi-Key; ComponentLink6URL: https://datasheet.ciiva.com/151/cx7r-151913.pdf?src-supplier=Digi-Key; ComponentLink7Description: https://datasheet.ciiva.com/7812/cx7r-7812105.pdf?src-supplier=Element14; ComponentLink7URL: https://datasheet.ciiva.com/7812/cx7r-7812105.pdf?src-supplier=Element14; ComponentLink8Description: https://datasheet.ciiva.com/16291/cx7r-16291545.pdf?src-supplier=Future+Electronics; ComponentLink8URL: https://datasheet.ciiva.com/16291/cx7r-16291545.pdf?src-supplier=Future+Electronics; ComponentLink9Description: https://datasheet.ciiva.com/151/cx7r-151914.pdf?src-supplier=Digi-Key; ComponentLink9URL: https://datasheet.ciiva.com/151/cx7r-151914.pdf?src-supplier=Digi-Key; ComponentLink10Description: https://datasheet.ciiva.com/151/cx7r-151915.pdf?src-supplier=Digi-Key; ComponentLink10URL: https://datasheet.ciiva.com/151/cx7r-151915.pdf?src-supplier=Digi-Key; ComponentLink11Description: https://datasheet.ciiva.com/25730/cl21a106koqnnng-25730226.pdf?src-supplier=Digi-Key; ComponentLink11URL: https://datasheet.ciiva.com/25730/cl21a106koqnnng-25730226.pdf?src-supplier=Digi-Key; ComponentLink12Description: https://datasheet.ciiva.com/29199/454932-da-01-en-vs-kondensator-0805-29199423.pdf?src-supplier=Conrad; ComponentLink12URL: https://datasheet.ciiva.com/29199/454932-da-01-en-vs-kondensator-0805-29199423.pdf?src-supplier=Conrad; ComponentLink13Description: https://datasheet.ciiva.com/25710/cl31a106kahnnne-25710837.pdf?src-supplier=Digi-Key; ComponentLink13URL: https://datasheet.ciiva.com/25710/cl31a106kahnnne-25710837.pdf?src-supplier=Digi-Key; ComponentLink14Description: https://datasheet.ciiva.com/15195/getdatasheetpartid-355210-15195990.pdf?src-supplier=Verical; ComponentLink14URL: https://datasheet.ciiva.com/15195/getdatasheetpartid-355210-15195990.pdf?src-supplier=Verical; ComponentLink15Description: https://datasheet.ciiva.com/29201/452519-da-01-en-vs-kondensator-1206-29201124.pdf?src-supplier=Conrad; ComponentLink15URL: https://datasheet.ciiva.com/29201/452519-da-01-en-vs-kondensator-1206-29201124.pdf?src-supplier=Conrad; ComponentLink16Description: https://datasheet.ciiva.com/7730/kem-c1002-x7r-smd-7730086.pdf?src-supplier=Element14; ComponentLink16URL: https://datasheet.ciiva.com/7730/kem-c1002-x7r-smd-7730086.pdf?src-supplier=Element14; ComponentLink17Description: https://datasheet.ciiva.com/6074/kem-c1002-x7r-smd-6074697.pdf?src-supplier=Farnell; ComponentLink17URL: https://datasheet.ciiva.com/6074/kem-c1002-x7r-smd-6074697.pdf?src-supplier=Farnell; ComponentLink18Description: https://datasheet.ciiva.com/22749/1918693-22749725.pdf?src-supplier=Element14; ComponentLink18URL: https://datasheet.ciiva.com/22749/1918693-22749725.pdf?src-supplier=Element14; Depth: 1.6mm; Dielectric Material: Ceramic; Height: 1mm; Length: 3.2mm; Library Name: LHA_LIB.SchLib; Max Operating Temperature: 125°C; Min Operating Temperature: -55°C; Mount: Surface Mount; Number of Pins: 2; Package Quantity: 1; PackageCase: 1206; Packaging: Tape and Reel; Pricing 1: 2500=0.06336, 5000=0.05861, 12500=0.05259, 25000=0.05069, 62500=0.04752, 125000=0.04198, 250000=0.03960 (USD); Radiation Hardening: No; REACH SVHC: No SVHC; RoHS: Yes; Stock 1: 97500; Supplier 1: Digi-Key; Supplier Part Number 1: 399-8147-2-ND; Termination: SMD/SMT; Thickness: 0.043inch; Tolerance: 10%; Width: 0.063inch
C21 = CAP: Cap Ceramic 10%; Manufacturer: KEMET; Manufacturer Part Number: C1206C105K5RACTU; Part Description: CAP X7R Ceramic 1uF 50V 1206 10%; Dielectric: X7R; LHADescription: Multilayer Ceramic Capacitors MLCC - SMD/SMT 50volts 1uF X7R 10%; Voltage Rated: 50V; Capacitance: 1uF; Case Code (Imperial): 1206; Case Code (Metric): 3216; Category: Capacitors; Component Kind: Standard; ComponentLink1Description: http://www.murata.com/~/media/webrenewal/support/library/catalog/products/capacitor/mlcc/c02e.ashx; ComponentLink1URL: http://www.murata.com/~/media/webrenewal/support/library/catalog/products/capacitor/mlcc/c02e.ashx; ComponentLink2Description: https://datasheet.ciiva.com/27056/2014035-27056208.pdf?src-supplier=Jameco; ComponentLink2URL: https://datasheet.ciiva.com/27056/2014035-27056208.pdf?src-supplier=Jameco; ComponentLink3Description: https://datasheet.ciiva.com/7812/cx7r-7812103.pdf?src-supplier=Element14; ComponentLink3URL: https://datasheet.ciiva.com/7812/cx7r-7812103.pdf?src-supplier=Element14; ComponentLink4Description: https://datasheet.ciiva.com/7812/cx7r-7812104.pdf?src-supplier=Element14; ComponentLink4URL: https://datasheet.ciiva.com/7812/cx7r-7812104.pdf?src-supplier=Element14; ComponentLink5Description: https://datasheet.ciiva.com/46441/1818074-46441208.pdf?src-supplier=Element14; ComponentLink5URL: https://datasheet.ciiva.com/46441/1818074-46441208.pdf?src-supplier=Element14; ComponentLink6Description: https://datasheet.ciiva.com/151/cx7r-151913.pdf?src-supplier=Digi-Key; ComponentLink6URL: https://datasheet.ciiva.com/151/cx7r-151913.pdf?src-supplier=Digi-Key; ComponentLink7Description: https://datasheet.ciiva.com/7812/cx7r-7812105.pdf?src-supplier=Element14; ComponentLink7URL: https://datasheet.ciiva.com/7812/cx7r-7812105.pdf?src-supplier=Element14; ComponentLink8Description: https://datasheet.ciiva.com/16291/cx7r-16291545.pdf?src-supplier=Future+Electronics; ComponentLink8URL: https://datasheet.ciiva.com/16291/cx7r-16291545.pdf?src-supplier=Future+Electronics; ComponentLink9Description: https://datasheet.ciiva.com/151/cx7r-151914.pdf?src-supplier=Digi-Key; ComponentLink9URL: https://datasheet.ciiva.com/151/cx7r-151914.pdf?src-supplier=Digi-Key; ComponentLink10Description: https://datasheet.ciiva.com/151/cx7r-151915.pdf?src-supplier=Digi-Key; ComponentLink10URL: https://datasheet.ciiva.com/151/cx7r-151915.pdf?src-supplier=Digi-Key; ComponentLink11Description: https://datasheet.ciiva.com/25730/cl21a106koqnnng-25730226.pdf?src-supplier=Digi-Key; ComponentLink11URL: https://datasheet.ciiva.com/25730/cl21a106koqnnng-25730226.pdf?src-supplier=Digi-Key; ComponentLink12Description: https://datasheet.ciiva.com/29199/454932-da-01-en-vs-kondensator-0805-29199423.pdf?src-supplier=Conrad; ComponentLink12URL: https://datasheet.ciiva.com/29199/454932-da-01-en-vs-kondensator-0805-29199423.pdf?src-supplier=Conrad; ComponentLink13Description: https://datasheet.ciiva.com/25710/cl31a106kahnnne-25710837.pdf?src-supplier=Digi-Key; ComponentLink13URL: https://datasheet.ciiva.com/25710/cl31a106kahnnne-25710837.pdf?src-supplier=Digi-Key; ComponentLink14Description: https://datasheet.ciiva.com/15195/getdatasheetpartid-355210-15195990.pdf?src-supplier=Verical; ComponentLink14URL: https://datasheet.ciiva.com/15195/getdatasheetpartid-355210-15195990.pdf?src-supplier=Verical; ComponentLink15Description: https://datasheet.ciiva.com/29201/452519-da-01-en-vs-kondensator-1206-29201124.pdf?src-supplier=Conrad; ComponentLink15URL: https://datasheet.ciiva.com/29201/452519-da-01-en-vs-kondensator-1206-29201124.pdf?src-supplier=Conrad; ComponentLink16Description: https://datasheet.ciiva.com/7730/kem-c1002-x7r-smd-7730086.pdf?src-supplier=Element14; ComponentLink16URL: https://datasheet.ciiva.com/7730/kem-c1002-x7r-smd-7730086.pdf?src-supplier=Element14; ComponentLink17Description: https://datasheet.ciiva.com/6074/kem-c1002-x7r-smd-6074697.pdf?src-supplier=Farnell; ComponentLink17URL: https://datasheet.ciiva.com/6074/kem-c1002-x7r-smd-6074697.pdf?src-supplier=Farnell; ComponentLink18Description: https://datasheet.ciiva.com/22749/1918693-22749725.pdf?src-supplier=Element14; ComponentLink18URL: https://datasheet.ciiva.com/22749/1918693-22749725.pdf?src-supplier=Element14; Depth: 1.6mm; Dielectric Material: Ceramic; Height: 1mm; Length: 3.2mm; Library Name: LHA_LIB.SchLib; Max Operating Temperature: 125°C; Min Operating Temperature: -55°C; Mount: Surface Mount; Number of Pins: 2; Package Quantity: 1; PackageCase: 1206; Packaging: Tape and Reel; Pricing 1: 2500=0.06336, 5000=0.05861, 12500=0.05259, 25000=0.05069, 62500=0.04752, 125000=0.04198, 250000=0.03960 (USD); Radiation Hardening: No; REACH SVHC: No SVHC; RoHS: Yes; Stock 1: 97500; Supplier 1: Digi-Key; Supplier Part Number 1: 399-8147-2-ND; Termination: SMD/SMT; Thickness: 0.043inch; Tolerance: 10%; Width: 0.063inch
D2 = LED: RED LED RA; Current - Test: 10mA; Lens Style/Size: Round with Domed Top, 3mm (T-1), 2.93mm; Lens Transparency: Diffused; LHADescription: LED RED DIFF 3MM ROUND T/H R/A; Millicandela Rating: 3.4mcd; Type: DIODE; Viewing Angle: 60°; Voltage - Forward (Vf) (Typ): 1.9V; Wavelength - Dominant: 626nm; Wavelength - Peak: 635nm; Category: Discrete Semiconductor Products; Color: Red; Component Kind: Standard; Features: -; Height (Max): 6.35mm; Lens Color: Red; Manufacturer Part Number: LTHLT631PR-H102; Mounting Type: Through Hole; PackageCase: Radial; Packaging: Bulk; Part Description: LED RED DIFF 3MM ROUND T/H R/A; Part Status: Active; RoHS: RoHS Compliant; Size / Dimension: -
D3 D8 = DIODE_1N4007: DIODE; Manufacturer: Fairchild Semiconductor; Capacitance @ Vr, F: 45pF @ 4V, 1MHz; Current - Average Rectified (Io): 3A; Current - Reverse Leakage @ Vr: 10µA @ 200V; Diode Type: Standard; LHADescription: DIODE GEN PURP 200V 3A SMC; Reverse Recovery Time (trr): 20ns; Speed: Fast Recovery =< 500ns, > 200mA (Io); Voltage - DC Reverse (Vr) (Max): 200V; Voltage - Forward (Vf) (Max) @ If: 950mV @ 3A; Category: Discrete Semiconductor Products; Component Kind: Standard; ComponentLink1Description: http://www.fairchildsemi.com/ds/ES/ES3A.pdf; ComponentLink1URL: http://www.fairchildsemi.com/ds/ES/ES3A.pdf; ComponentLink2Description: https://www.fairchildsemi.com/datasheets/ES/ES3A.pdf; ComponentLink2URL: https://www.fairchildsemi.com/datasheets/ES/ES3A.pdf; Library Name: LHA_LIB.SchLib; Manufacturer Part Number: ES3D; Mounting Type: Surface Mount; Operating Temperature - Junction: -50°C ~ 150°C; PackageCase: DO-214AB, SMC; Packaging: Cut Tape (CT); Part Description: DIODE GEN PURP 200V 3A SMC; Part Status: Active; Pricing: 1=0.55, 10=0.429, 25=0.3624, 100=0.2953, 250=0.2446, 500=0.20204, 1000=0.15133 (USD); Pricing 2: 3000=0.15523, 6000=0.14582, 15000=0.13642, 30000=0.12544 (USD); RoHS: RoHS Compliant; Stock: 14271; Stock 2: 36000; Supplier 1: Digi-Key; Supplier 2: Digi-Key; Supplier Device Package: SMC; Supplier Part Number 1: ES3DFSCT-ND; Supplier Part Number 2: ES3DFSTR-ND; Type: DIODE
D4 = D Zener: Zener Diode DO-35; Manufacturer: Bourns; Breakdown Voltage: 192V; Clamping Voltage: 243V; Direction: Unidirectional; Element Configuration: Single; Leakage Current: 5uA; LHADescription: TVS DIODE 150V 243V SMB; Max Surge Current: 100A; Min Breakdown Voltage: 167V; Number of Channels: 1; Operating Supply Voltage: 5V; Peak Pulse Current: 2.5A; Peak Pulse Power: 600W; Power Line Protection: No; Reverse Standoff Voltage: 150V; Test Current: 1mA; Category: Discrete Semiconductor Products; Component Kind: Standard; ComponentLink1Description: https://datasheet.ciiva.com/16210/ds19002-16210985.pdf?src-supplier=Future+Electronics; ComponentLink1URL: https://datasheet.ciiva.com/16210/ds19002-16210985.pdf?src-supplier=Future+Electronics; ComponentLink2Description: https://datasheet.ciiva.com/17413/smbj-17413592.pdf?src-supplier=Avnet+Europe; ComponentLink2URL: https://datasheet.ciiva.com/17413/smbj-17413592.pdf?src-supplier=Avnet+Europe; ComponentLink3Description: https://datasheet.ciiva.com/334/smbj-334172.pdf?src-supplier=Digi-Key; ComponentLink3URL: https://datasheet.ciiva.com/334/smbj-334172.pdf?src-supplier=Digi-Key; ComponentLink4Description: https://datasheet.ciiva.com/334/smbj-334173.pdf?src-supplier=Digi-Key; ComponentLink4URL: https://datasheet.ciiva.com/334/smbj-334173.pdf?src-supplier=Digi-Key; ComponentLink5Description: https://datasheet.ciiva.com/334/smbj-334174.pdf?src-supplier=Digi-Key; ComponentLink5URL: https://datasheet.ciiva.com/334/smbj-334174.pdf?src-supplier=Digi-Key; ComponentLink6Description: https://datasheet.ciiva.com/3022/smbj-3022430.pdf?src-supplier=Avnet; ComponentLink6URL: https://datasheet.ciiva.com/3022/smbj-3022430.pdf?src-supplier=Avnet; ComponentLink7Description: https://datasheet.ciiva.com/3022/smbj-3022431.pdf?src-supplier=Avnet; ComponentLink7URL: https://datasheet.ciiva.com/3022/smbj-3022431.pdf?src-supplier=Avnet; Composition: Zener; Library Name: LHA_LIB.SchLib; Manufacturer Part Number: SMBJ150A; Max Operating Temperature: 150°C; Max Reverse Leakage Current: 5uA; Min Operating Temperature: -55°C; Mount: Surface Mount; Number of Elements: 1; Number of Pins: 2; Number of Unidirectional Channels: 1; Package Quantity: 1; PackageCase: SMB; Packaging: Tape and Reel; Part Description: TVS DIODE 150V 243V SMB; Polarity: Unidirectional; Pricing 1: 3000=0.08784, 6000=0.08400, 15000=0.07440, 30000=0.07344, 75000=0.06240, 150000=0.06000 (USD); Radiation Hardening: No; Reverse Breakdown Voltage: 167V; RoHS: Yes; Signal Integrity: D Zener; Simulation: ZENER; Stock 1: 9000; Supplier 1: Digi-Key; Supplier Part Number 1: SMBJ150ABTR-ND; Termination: SMD/SMT
D5 = DIODE: Manufacturer: Vishay Semiconductor Diodes Division; Capacitance @ Vr, F: 4pF @ 0V, 1MHz; Current - Average Rectified (Io): 300mA (DC); Current - Reverse Leakage @ Vr: 5µA @ 75V; Diode Type: Standard; LHADescription: DIODE GEN PURP 75V 300MA SOD80; Reverse Recovery Time (trr): 4ns; Speed: Fast Recovery =< 500ns, > 200mA (Io); Voltage - DC Reverse (Vr) (Max): 75V; Voltage - Forward (Vf) (Max) @ If: 1V @ 50mA; Category: Discrete Semiconductor Products; Component Kind: Standard; ComponentLink1Description: http://www.vishay.com/docs/85557/ll4148.pdf; ComponentLink1URL: http://www.vishay.com/docs/85557/ll4148.pdf; Description: DIODE; Library Name: LHA_LIB.SchLib; Manufacturer Part Number: LL4148; Mounting Type: Surface Mount; Operating Temperature - Junction: -65°C ~ 175°C; PackageCase: SOD-80; Packaging: Cut Tape (CT); Part Description: DIODE GEN PURP 75V 300MA SOD80; Part Status: Active; Pricing 1: 1=0.15, 10=0.135, 25=0.1284, 100=0.0735, 250=0.05448, 500=0.04522, 1000=0.03084, 2500=0.02621, 5000=0.02364 (USD); RoHS: RoHS Compliant; Stock 1: 249840; Supplier 1: Digi-Key; Supplier Device Package: SOD-80 MiniMELF; Supplier Part Number 1: LL4148-GS08CT-ND; Type: DIODE
D6 = DIODE: Manufacturer: Diodes; Average Rectified Current: 3A; Capacitance: 40pF; Capacitance @ Vr, F: 10pF @ 4V, 1MHz; Element Configuration: Single; Forward Voltage: 1.3V; LHADescription: DIODE GEN PURP 1KV 3A SMB; Max Repetitive Reverse Voltage (Vrrm): 1kV; Max Surge Current: 100A; Peak Reverse Current: 5uA; Polarity: Standard; Recovery Time: 500ns; Category: Discrete Semiconductor Products; Component Kind: Standard; ComponentLink1Description: http://www.mccsemi.com/up_pdf/US1A-US1M(DO-214AC).pdf; ComponentLink1URL: http://www.mccsemi.com/up_pdf/US1A-US1M(DO-214AC).pdf; ComponentLink2Description: https://datasheet.ciiva.com/25946/ds29003-25946805.pdf?src-supplier=Avnet+Europe; ComponentLink2URL: https://datasheet.ciiva.com/25946/ds29003-25946805.pdf?src-supplier=Avnet+Europe; ComponentLink3Description: https://datasheet.ciiva.com/8301/ds29003-8301542.pdf?src-supplier=Avnet; ComponentLink3URL: https://datasheet.ciiva.com/8301/ds29003-8301542.pdf?src-supplier=Avnet; ComponentLink4Description: https://datasheet.ciiva.com/26788/rs3mb-13-f-26788601.pdf?src-supplier=IIIC; ComponentLink4URL: https://datasheet.ciiva.com/26788/rs3mb-13-f-26788601.pdf?src-supplier=IIIC; ComponentLink5Description: https://datasheet.ciiva.com/16483/ds15005-16483534.pdf?src-supplier=Future+Electronics; ComponentLink5URL: https://datasheet.ciiva.com/16483/ds15005-16483534.pdf?src-supplier=Future+Electronics; ComponentLink6Description: https://datasheet.ciiva.com/914/ds15005-914726.pdf?src-supplier=Digi-Key; ComponentLink6URL: https://datasheet.ciiva.com/914/ds15005-914726.pdf?src-supplier=Digi-Key; ComponentLink7Description: https://datasheet.ciiva.com/914/ds15005-914727.pdf?src-supplier=Digi-Key; ComponentLink7URL: https://datasheet.ciiva.com/914/ds15005-914727.pdf?src-supplier=Digi-Key; ComponentLink8Description: https://datasheet.ciiva.com/25953/ds15005-25953031.pdf?src-supplier=Avnet+Europe; ComponentLink8URL: https://datasheet.ciiva.com/25953/ds15005-25953031.pdf?src-supplier=Avnet+Europe; ComponentLink9Description: https://datasheet.ciiva.com/914/ds15005-914728.pdf?src-supplier=Digi-Key; ComponentLink9URL: https://datasheet.ciiva.com/914/ds15005-914728.pdf?src-supplier=Digi-Key; Current Rating: 3A; Description: DIODE; Forward Current: 3A; Height: 2.42mm; Lead Free: Lead Free; Length: 4.57mm; Library Name: LHA_LIB.SchLib; Manufacturer Part Number: RS3MB; Max Operating Temperature: 150°C; Max Reverse Voltage (DC): 1kV; Min Operating Temperature: -65°C; Mount: Surface Mount,Stud; Number of Pins: 2; Output Current: 3A; Package Quantity: 3000; PackageCase: SMB; Packaging: Tape and Reel; Part Description: DIODE GEN PURP 1KV 3A SMB; Peak Non-Repetitive Surge Current: 100A; Pricing 1: 3000=0.21700, 6000=0.20300, 15000=0.19600 (USD); Radiation Hardening: No; Reverse Recovery Time: 500ns; Reverse Voltage (DC): 1kV; RoHS: Yes; Stock 1: 27000; Supplier 1: Digi-Key; Supplier Part Number 1: RS3MB; Voltage Rating (DC): 1kV; Weight: 0.00328oz; Width: 3.94mm
